# Altium schematic sheet FPGA_SPI_JTAG_IO.SchDoc(FPGA_SPI_JTAG_IO)
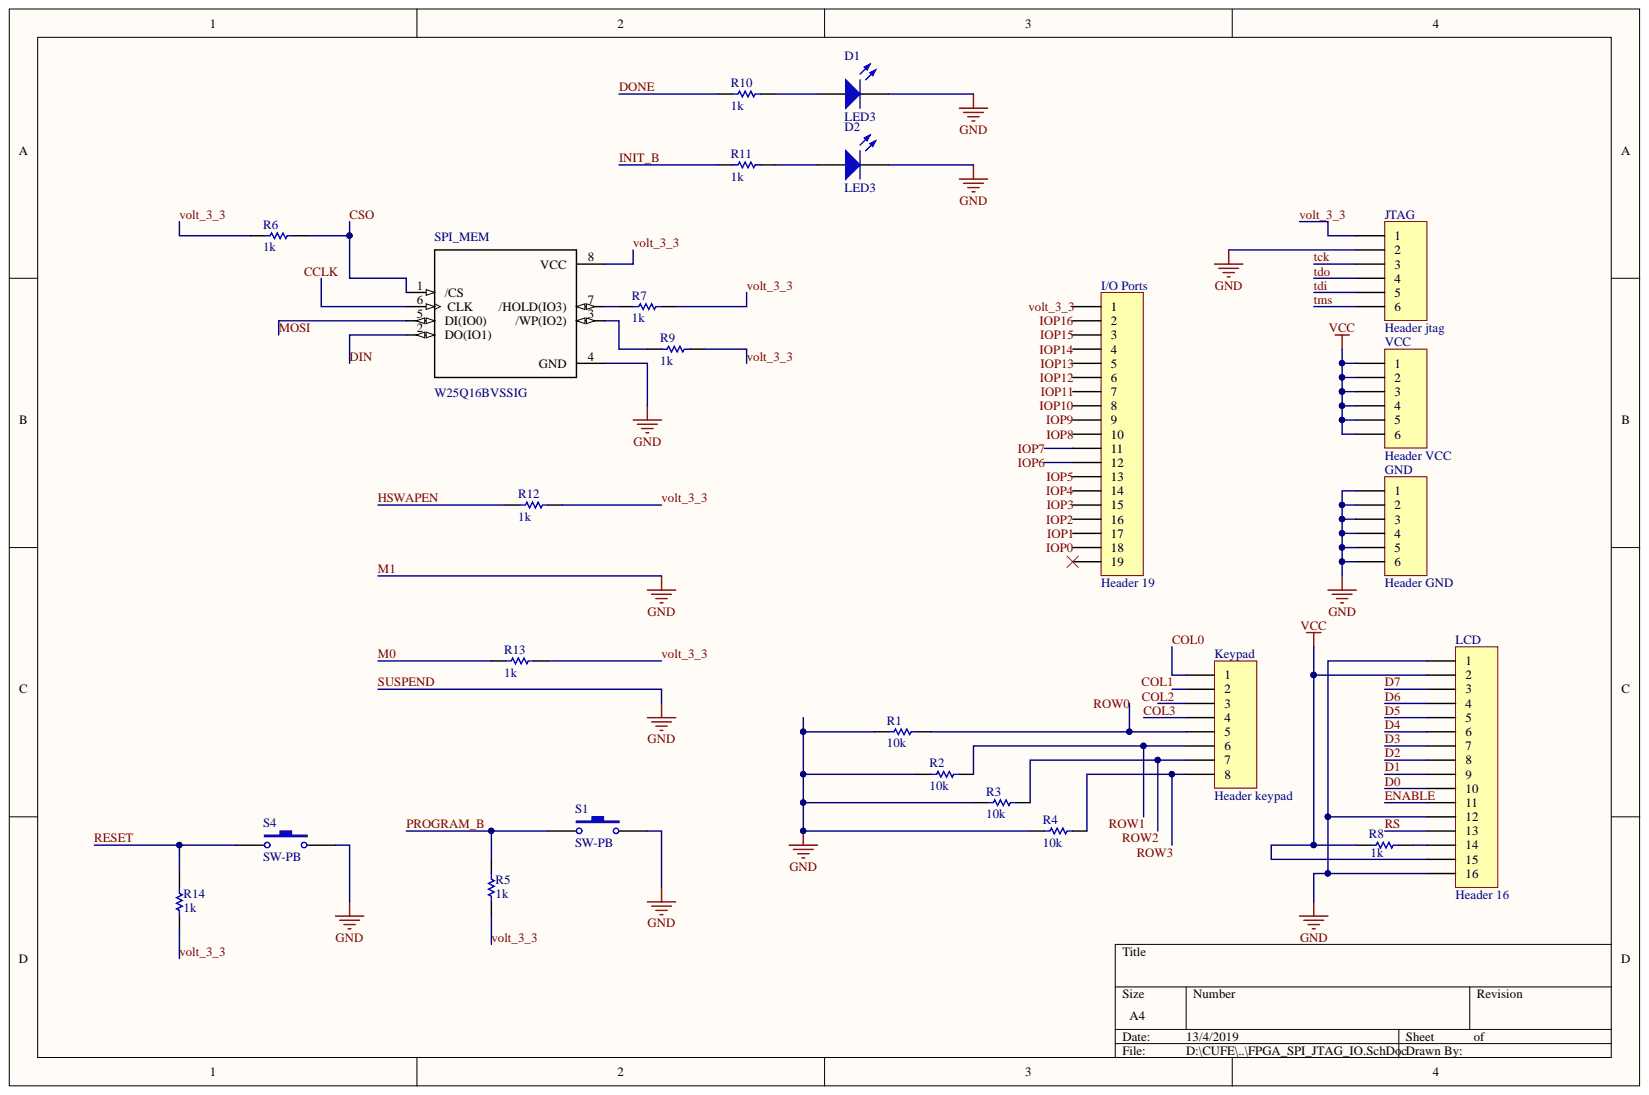
[diagram p0: FPGA_SPI_JTAG_IO.SchDoc]

## Parts
D1  LED3  [3.5X2.8X1.9]
D2  LED3  [3.5X2.8X1.9]
GND  Header GND  [HDR1X6]
I/O_Ports  Header 19  [HDR1X19]
JTAG  Header jtag  [HDR1X6]
Keypad  Header keypad  [HDR1X8]
LCD  Header 16  [HDR1X16]
R1  10k  [ERA-0805]
R2  10k  [ERA-0805]
R3  10k  [ERA-0805]
R4  10k  [ERA-0805]
R5  1k  [ERA-0805]
R6  1k  [ERA-0805]
R7  1k  [ERA-0805]
R8  1k  [ERA-0805]
R9  1k  [ERA-0805]
R10  1k  [ERA-0805]
R11  1k  [ERA-0805]
R12  1k  [ERA-0805]
R13  1k  [ERA-0805]
R14  1k  [ERA-0805]
S1  SW-PB  [SPST-2]
S4  SW-PB  [SPST-2]
SPI_MEM  W25Q16BVSSIG  [*SOIC127P790X216-8N]
VCC  Header VCC  [HDR1X6]

## Nets
VCC: LCD.2 LCD.15 R8.1 VCC.1 VCC.2 VCC.3 VCC.4 VCC.5 VCC.6
CCLK: SPI_MEM.6(CLK)
COL0: Keypad.1
COL1: Keypad.2
COL2: Keypad.3
COL3: Keypad.4
CSO: R6.2 SPI_MEM.1(/CS)
D0: LCD.10
D1: LCD.9
D2: LCD.8
D3: LCD.7
D4: LCD.6
D5: LCD.5
D6: LCD.4
D7: LCD.3
DIN: SPI_MEM.2(DO(IO1))
DONE: R10.1
ENABLE: LCD.11
HSWAPEN: R12.2
INIT_B: R11.1
IOP0: I/O_Ports.18
IOP1: I/O_Ports.17
IOP2: I/O_Ports.16
IOP3: I/O_Ports.15
IOP4: I/O_Ports.14
IOP5: I/O_Ports.13
IOP6: I/O_Ports.12
IOP7: I/O_Ports.11
IOP8: I/O_Ports.10
IOP9: I/O_Ports.9
IOP10: I/O_Ports.8
IOP11: I/O_Ports.7
IOP12: I/O_Ports.6
IOP13: I/O_Ports.5
IOP14: I/O_Ports.4
IOP15: I/O_Ports.3
IOP16: I/O_Ports.2
M0: R13.2
M1: D1.2 D2.2 GND.1 GND.2 GND.3 GND.4 GND.5 GND.6 JTAG.2 LCD.1 LCD.12 LCD.16 R1.1 R2.1 R3.1 R4.1 S1.2 S4.2 SPI_MEM.4(GND)
MOSI: SPI_MEM.5(DI(IO0))
PROGRAM_B: R5.2 S1.1
RESET: R14.2 S4.1
ROW0: Keypad.5 R1.2
ROW1: Keypad.6 R2.2
ROW2: Keypad.7 R3.2
ROW3: Keypad.8 R4.2
RS: LCD.13
tck: JTAG.3
tdi: JTAG.5
tdo: JTAG.4
tms: JTAG.6
volt_3_3: I/O_Ports.1 JTAG.1 R5.1 R6.1 R7.1 R9.1 R12.1 R13.1 R14.1 SPI_MEM.8(VCC)
NetD1_1: D1.1 R10.2
NetD2_1: D2.1 R11.2
NetLCD_14: LCD.14 R8.2
NetR7_2: R7.2 SPI_MEM.7(/HOLD(IO3))
NetR9_2: R9.2 SPI_MEM.3(/WP(IO2))

Not connected: I/O_Ports 19

## Library data of the parts
D1 D2 = LED3: Typical BLUE SiC LED; Simulation: LED3; Signal Integrity: LED; Published: 8-Jun-2000; PackageVersion: 16-Apr-2002; PackageReference: 3.5X2.8X1.9; PackageDescription: SM LED; 2 C-Bend Leads; Body 3.5 x 2.8 x 1.9 mm, inc leads (LxWxH typ); Library Name: Miscellaneous Devices.IntLib; LatestRevisionNote: PCB Footprint replaced.; LatestRevisionDate: 17-Dec-2003; DatasheetVersion: 16-Apr-2002; Component Kind: Standard; Publisher: Altium Limited
GND JTAG VCC = Header 6: Header, 6-Pin; Signal Integrity: Connector; Library Name: Miscellaneous Connectors.IntLib; LatestRevisionNote: Re-released for DXP Platform.; LatestRevisionDate: 17-Jul-2002; Component Kind: Standard; Publisher: Altium Limited
I/O_Ports = Header 19: Header, 19-Pin; Signal Integrity: Connector; Library Name: Miscellaneous Connectors.IntLib; LatestRevisionNote: Re-released for DXP Platform.; LatestRevisionDate: 17-Jul-2002; Component Kind: Standard; Publisher: Altium Limited
Keypad = Header 8: Header, 8-Pin; Signal Integrity: Connector; Library Name: Miscellaneous Connectors.IntLib; LatestRevisionNote: Re-released for DXP Platform.; LatestRevisionDate: 17-Jul-2002; Component Kind: Standard; Publisher: Altium Limited
LCD = Header 16: Header, 16-Pin; Signal Integrity: Connector; Library Name: Miscellaneous Connectors.IntLib; LatestRevisionNote: Re-released for DXP Platform.; LatestRevisionDate: 17-Jul-2002; Component Kind: Standard; Publisher: Altium Limited
R1-R14 = ERA6Y: Rectangular Thick Film Chip Resistor, 10 Ohm to 1M Ohm Range, 0.1% and 0.5% Tolerance, 0805 Size, 0.1 W; Note: If flow soldering, adjust pad width of PCB Footprint to 0.7 - 0.8 times the width of the resistor.; Simulation: RESISTOR; Signal Integrity: Res; Published: 17-Dec-2003; PackageReference: ERA-0805; PackageDocument: Mar-2003; Library Name: Panasonic Resistor.IntLib; LatestRevisionNote: Silkscreen size reduced.; LatestRevisionDate: 19-Mar-2004; ComponentLink2URL: http://www.panasonic.com/industrial/components/pdf/AOA0000CE8.pdf; ComponentLink2Description: Datasheet; ComponentLink1URL: http://www.panasonic.com/industrial/components/resistive_products.htm; ComponentLink1Description: Manufacturer Link; Component Kind: Standard; Code_IPC: 2012 [0805]; Publisher: Altium Limited
S1 S4 = SW-PB: Switch; Published: 24-Mar-1999; PackageReference: SPST-2; PackageDescription: Switch; 2 Leads; Library Name: Miscellaneous Devices.IntLib; LatestRevisionNote: Re-released for DXP Platform.; LatestRevisionDate: 17-Jul-2002; Component Kind: Standard; Publisher: Altium Limited
SPI_MEM = W25Q16BVSSIG: Flash, pin, soic, flash, Memory, Semiconductors and Actives, serial, ic; MF: Winbond; SnapEDA_Link: https://www.snapeda.com/parts/W25Q16BVSSIG/Winbond%20Electronics/view-part/?ref=snap; Package: SOIC-8 Winbond; MP: W25Q16BVSSIG; Library Name: W25Q16BVSSIG.IntLib; Digi-Key_Purchase_URL: https://www.digikey.com/product-detail/en/winbond-electronics/W25Q16BVSSIG/W25Q16BVSSIG-ND/2208449?utm_source=snapeda&utm_medium=aggregator&utm_campaign=symbol; Digi-Key_Part_Number: W25Q16BVSSIG-ND; Component Kind: Standard
